# Altium schematic sheet DelayLine.SchDoc
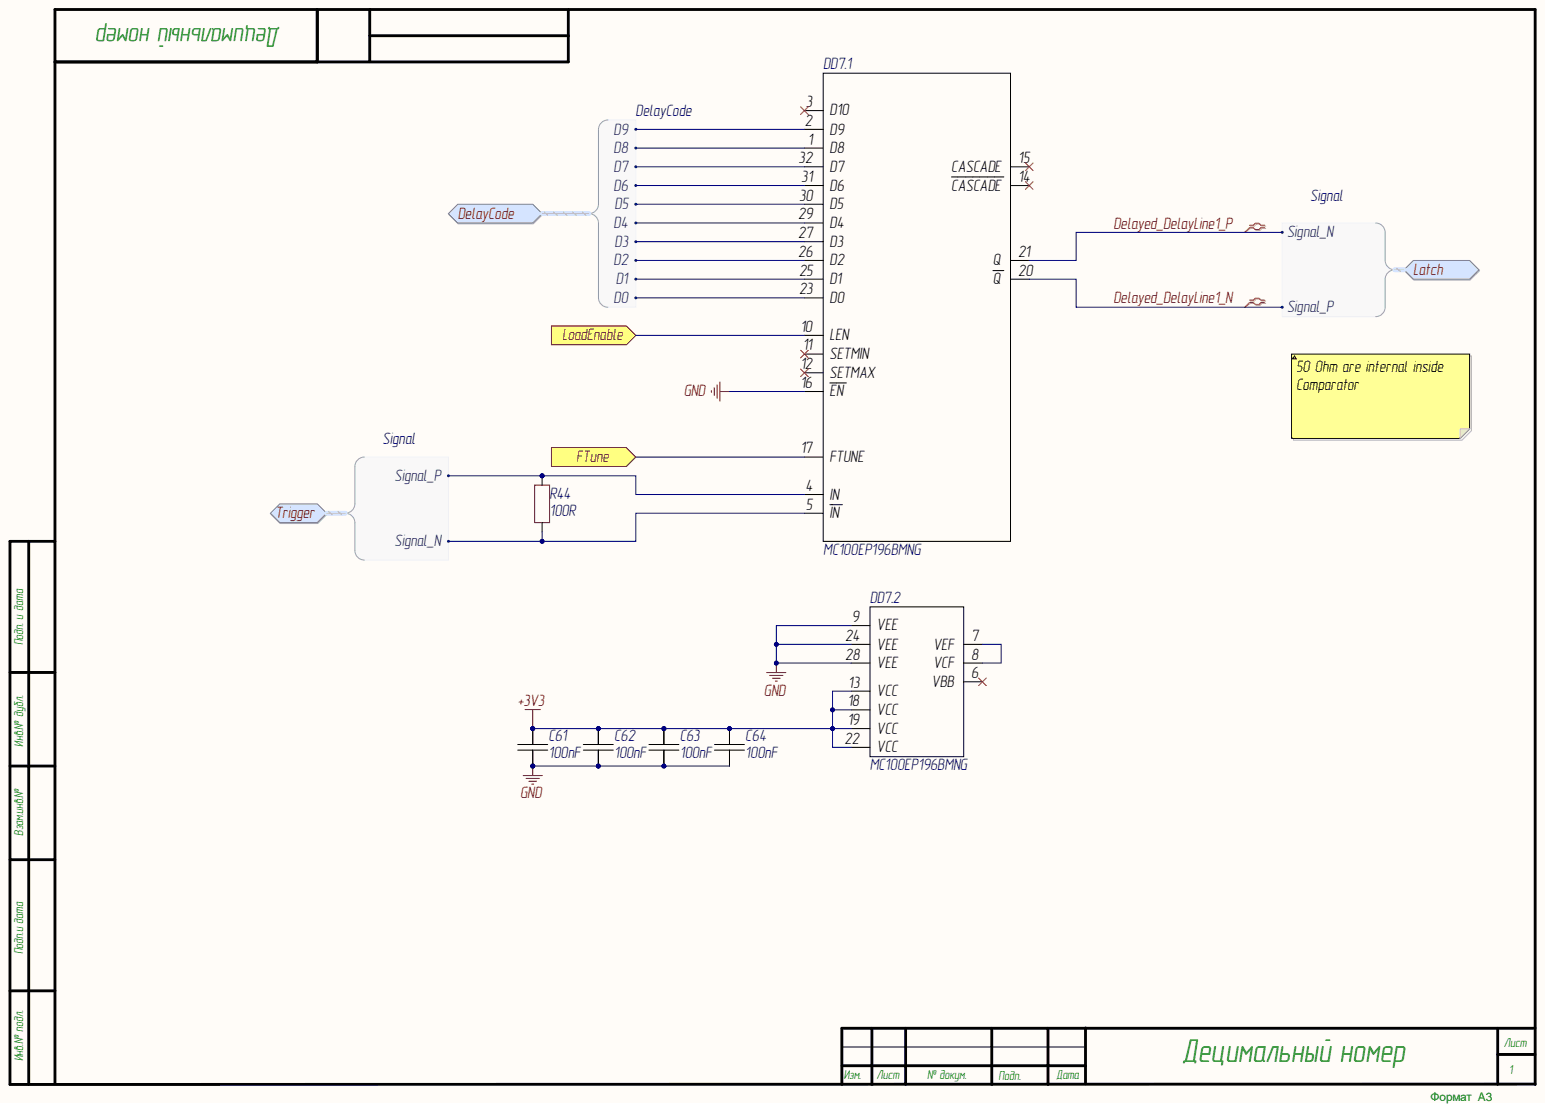
[diagram p0: DelayLine.SchDoc]

## Parts
C61  100nF *  value 100nF  [CAP0402]
C62  100nF *  value 100nF  [CAP0402]
C63  100nF *  value 100nF  [CAP0402]
C64  100nF *  value 100nF  [CAP0402]
DD7  MC100EP196BMNG  [QFN-32_N_TP]  (parts .1,.2)
R44  100R  [RESC0402(1005)_M]

## Ports
DelayCode, DelayCode.D0, DelayCode.D1, DelayCode.D2, DelayCode.D3, DelayCode.D4, DelayCode.D5, DelayCode.D6, DelayCode.D7, DelayCode.D8, DelayCode.D9, FTune, Latch, Latch.Signal_N, Latch.Signal_P, LoadEnable, Trigger, Trigger.Signal_N, Trigger.Signal_P

## Nets
+3V3: C61.1 C62.1 C63.1 C64.1 DD7.13(VCC) DD7.18(VCC) DD7.19(VCC) DD7.22(VCC)
GND: C61.2 C62.2 C63.2 C64.2 DD7.9(VEE) DD7.16(EN) DD7.24(VEE) DD7.28(VEE)
Delayed_DelayLine1_N: DD7.20(Q) ; port Latch
Delayed_DelayLine1_P: DD7.21(Q) ; port Latch
FTune: DD7.17(FTUNE) ; port FTune
LoadEnable: DD7.10(LEN) ; port LoadEnable
NetDD7_1: DD7.1(D8) ; port DelayCode
NetDD7_2: DD7.2(D9) ; port DelayCode
NetDD7_4: DD7.4(IN) R44.2 ; port Trigger
NetDD7_5: DD7.5(IN) R44.1 ; port Trigger
NetDD7_7: DD7.7(VEF) DD7.8(VCF)
NetDD7_23: DD7.23(D0) ; port DelayCode
NetDD7_25: DD7.25(D1) ; port DelayCode
NetDD7_26: DD7.26(D2) ; port DelayCode
NetDD7_27: DD7.27(D3) ; port DelayCode
NetDD7_29: DD7.29(D4) ; port DelayCode
NetDD7_30: DD7.30(D5) ; port DelayCode
NetDD7_31: DD7.31(D6) ; port DelayCode
NetDD7_32: DD7.32(D7) ; port DelayCode

Not connected: DD7 3(D10) 6(VBB) 11(SETMIN) 12(SETMAX) 14(CASCADE) 15(CASCADE)

## Library data of the parts
C61-C64 = CAP0402: BOMDescription: SMD CHIP CERAMIC CAPACITOR CAP0402 100nF  *; Database Table Name: Symbol_SchLib; MntType: SMD; CFootprint: CAP0402; ClassName: Capacitor; Component Kind: Standard; ComponentLink1Description: Datasheet; Library Name: Library.DbLib; MSL: MSL 1; Package: CAP0402; Part Number: CAP0402; PartType: CHIP CERAMIC CAPACITOR; Pins: 2; RoHS: Comply
DD7 = MC100EP196BMNG: Manufacturer: ONSEMI; BOMDescription: SMD Delay Line QFN-32 =Value    MC100EP196BMNG; Database Table Name: Symbol_SchLib; MntType: SMD; CFootprint: QFN-32_N_TP; ClassName: DelayLine; Component Kind: Standard; ComponentLink1Description: Datasheet; ComponentLink1URL: https://eu.mouser.com/datasheet/2/308/1/MC100EP196B_D-2315397.pdf; Library Name: Library.DbLib; MPN: MC100EP196BMNG; MSL: MSL 1; Package: QFN-32; Part Number: MC100EP196BMNG; PartType: Delay Line; Pins: 32; RoHS: Comply
R44 = RES0402: BOMDescription: SMD CHIP RESISTOR RESC0402(1005)_M 100R; Database Table Name: Symbol_SchLib; MntType: SMD; CFootprint: RESC0402(1005)_M; ClassName: Resistor; Component Kind: Standard; ComponentLink1Description: Datasheet; Library Name: Library.DbLib; MSL: MSL 1; Package: RESC0402(1005)_M; Part Number: RES0402; PartType: CHIP RESISTOR; Pins: 2; RoHS: Comply; Value: 100R
